# Altium schematic sheet [6]RGBs_2.SchDoc([6]RGBs_2)
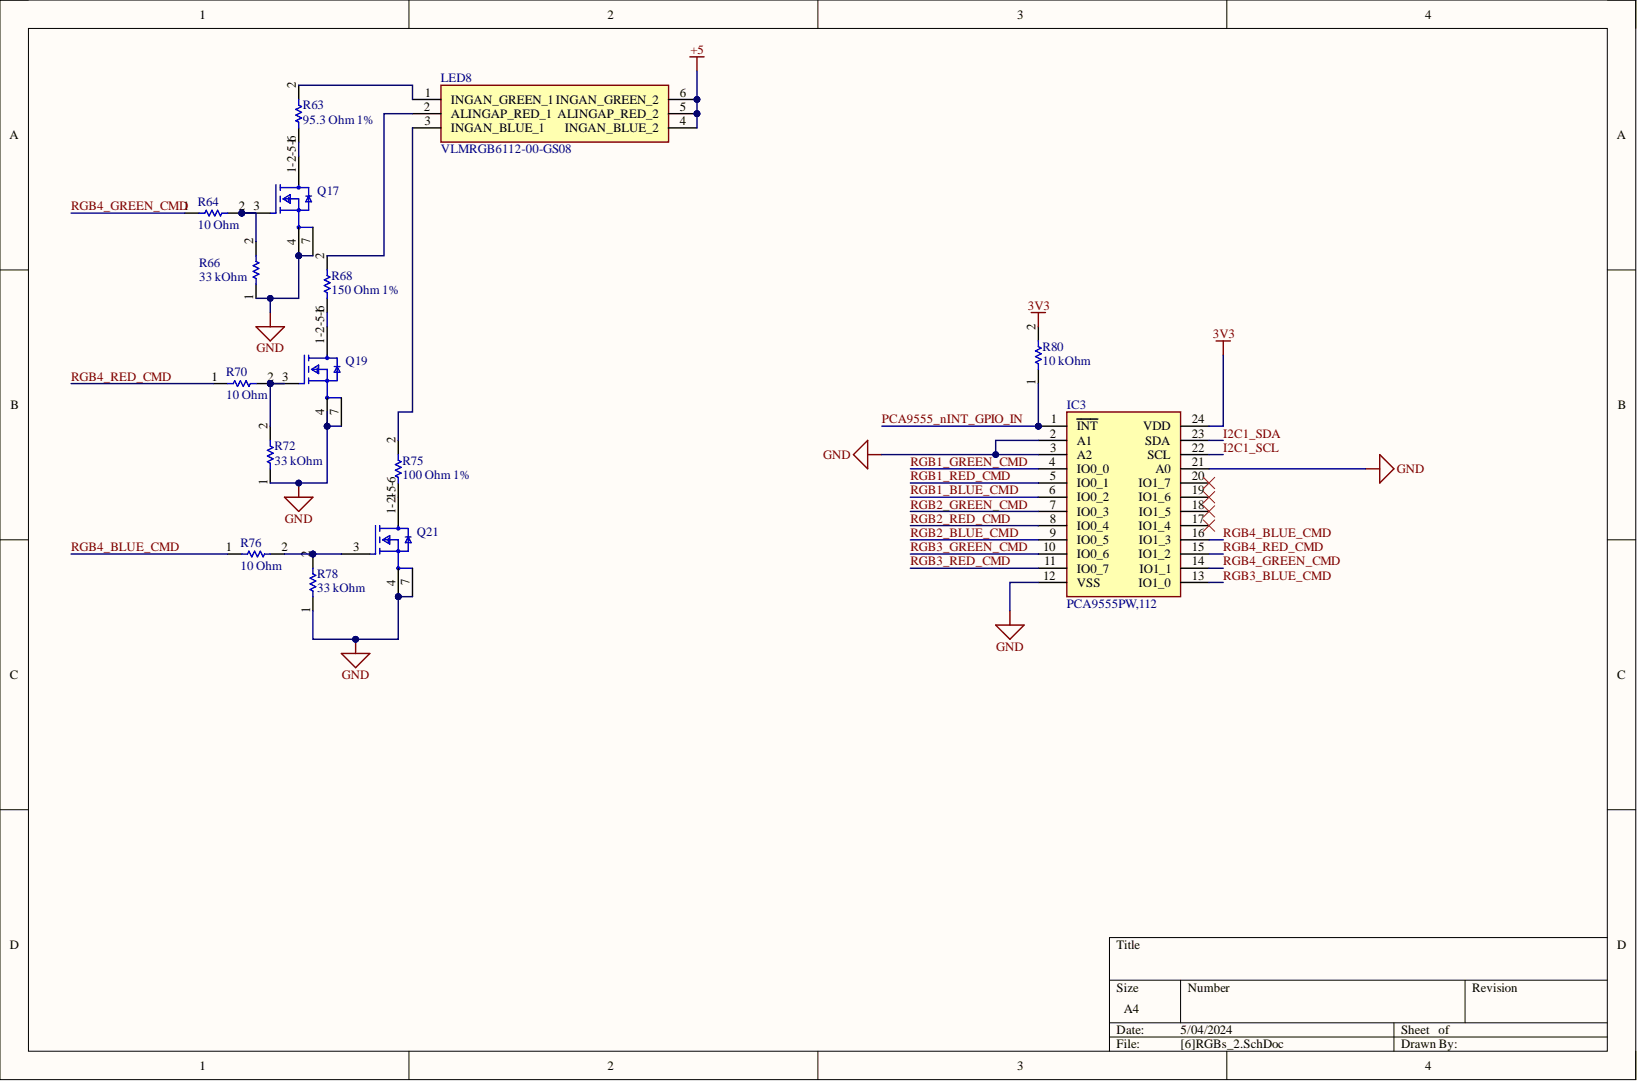
[diagram p0: [6]RGBs_2.SchDoc]

## Parts
IC3  PCA9555PW,112
LED8  VLMRGB6112-00-GS08
Q17  SIA440DJ-T1-GE3  (value not drawn)
Q19  SIA440DJ-T1-GE3  (value not drawn)
Q21  SIA440DJ-T1-GE3  (value not drawn)
R63  95.3 Ohm 1%
R64  10 Ohm
R66  33 kOhm
R68  150 Ohm 1%
R70  10 Ohm
R72  33 kOhm
R75  100 Ohm 1%
R76  10 Ohm
R78  33 kOhm
R80  10 kOhm

## Nets
3V3: IC3.24(VDD) R80.2
+5: LED8.4(INGAN_BLUE_2) LED8.5(ALINGAP_RED_2) LED8.6(INGAN_GREEN_2)
GND: IC3.2(A1) IC3.3(A2) IC3.12(VSS) IC3.21(A0) Q17.4 Q17.7 Q19.4 Q19.7 Q21.4 Q21.7 R66.1 R72.1 R78.1
I2C1_SCL: IC3.22(SCL)
I2C1_SDA: IC3.23(SDA)
PCA9555_nINT_GPIO_IN: IC3.1(INT) R80.1
RGB1_BLUE_CMD: IC3.6(IO0_2)
RGB1_GREEN_CMD: IC3.4(IO0_0)
RGB1_RED_CMD: IC3.5(IO0_1)
RGB2_BLUE_CMD: IC3.9(IO0_5)
RGB2_GREEN_CMD: IC3.7(IO0_3)
RGB2_RED_CMD: IC3.8(IO0_4)
RGB3_BLUE_CMD: IC3.13(IO1_0)
RGB3_GREEN_CMD: IC3.10(IO0_6)
RGB3_RED_CMD: IC3.11(IO0_7)
RGB4_BLUE_CMD: IC3.16(IO1_3) R76.1
RGB4_GREEN_CMD: IC3.14(IO1_1) R64.1
RGB4_RED_CMD: IC3.15(IO1_2) R70.1
NetLED8_1: LED8.1(INGAN_GREEN_1) R63.2
NetLED8_2: LED8.2(ALINGAP_RED_1) R68.2
NetLED8_3: LED8.3(INGAN_BLUE_1) R75.2
NetQ17_1-2-5-6: Q17.1-2-5-6 R63.1
NetQ17_3: Q17.3 R64.2 R66.2
NetQ19_1-2-5-6: Q19.1-2-5-6 R68.1
NetQ19_3: Q19.3 R70.2 R72.2
NetQ21_1-2-5-6: Q21.1-2-5-6 R75.1
NetQ21_3: Q21.3 R76.2 R78.2

Not connected: IC3 17(IO1_4) 18(IO1_5) 19(IO1_6) 20(IO1_7)

## Library data of the parts
IC3 = CMP-030-000057-1: PCA9555 16-bit I2C-bus and SMBus I/O port with interrupt; Manufacturer_Name: NXP; Arrow Part Number: PCA9555PW,112; Arrow Price/Stock: https://www.arrow.com/en/products/pca9555pw112/nxp-semiconductors?region=europe; Component Kind: Standard; Datasheet Link: http://www.nxp.com/docs/en/data-sheet/PCA9555.pdf; Design Item ID: PCA9555PW,112; Height: 1.1mm; Manufacturer_Part_Number: PCA9555PW,112; Mouser Part Number: 771-PCA9555PW; Mouser Price/Stock: https://www.mouser.co.uk/ProductDetail/NXP-Semiconductors/PCA9555PW112?qs=LOCUfHb8d9uevXd%252BxAh4lA%3D%3D; Source: SamacSys.SchLib; Library Name: EMEA- Squadra Corse PoliTO-  Politecnico di Torino
LED8 = CMP-031-000019-1: Vishay VLMRGB6112-00-GS08; Arrow Part Number: VLMRGB6112-00-GS08; Arrow Price/Stock: https://www.arrow.com/en/products/vlmrgb6112-00-gs08/vishay?region=nac; Component Kind: Standard; Datasheet Link: https://www.vishay.com/docs/84342/vlmrgb6112.pdf; Design Item ID: VLMRGB6112-00-GS08; Height: 1.45mm; Manufacturer_Name: Vishay; Manufacturer_Part_Number: VLMRGB6112-00-GS08; Mouser Part Number: 78-VLMRGB6112-00GS08; Mouser Price/Stock: https://www.mouser.co.uk/ProductDetail/Vishay-Semiconductors/VLMRGB6112-00-GS08?qs=r0GWHu4zPW7d7ePE7SUMgQ%3D%3D; Source: SamacSys.SchLib; Library Name: EMEA- Squadra Corse PoliTO-  Politecnico di Torino
Q17 Q19 Q21 = CMP-02550-000315-1: MOSFET N-CH 40V 12A PPAK SC70-6; Drain to Source Resistance: 26mR; Element Configuration: Single; Fall Time: 5ns; Gate to Source Voltage (Vgs): 12V; Input Capacitance: 700pF; Max Power Dissipation: 19W; Number of Channels: 1; Polarity: N-CHANNEL; Rise Time: 32ns; Turn-Off Delay Time: 23ns; Turn-On Delay Time: 12ns; Component Kind: Standard; Continuous Drain Current (ID): 12A; Drain to Source Breakdown Voltage: 40V; Drain to Source Voltage (Vdss): 40V; Height: 0.75mm; Length: 2.05mm; Library Name: Altium Content Vault; Max Operating Temperature: 150°C; Min Operating Temperature: -55°C; Mount: Surface Mount; Number of Pins: 6; Package Quantity: 3000; Packaging: Tape and Reel; Radiation Hardening: No; Rds On Max: 26mR; RoHS Compliant: Yes; Series: TrenchFET®; Width: 2.05mm
R63 = CMP-00010-3: CRCW060395R3FKTA; Component Kind: Standard; Library Name: EMEA- Squadra Corse PoliTO-  Politecnico di Torino
R64 R70 R76 = CMP-00010-3: CRCW060310R0FKEAHP; Component Kind: Standard; Library Name: EMEA- Squadra Corse PoliTO-  Politecnico di Torino
R66 R72 R78 = CMP-00010-3: CRCW060333K0JNEA; Component Kind: Standard; Library Name: EMEA- Squadra Corse PoliTO-  Politecnico di Torino
R68 = CMP-00010-3: CRCU0603150RFKEAHP; Component Kind: Standard; Library Name: EMEA- Squadra Corse PoliTO-  Politecnico di Torino
R75 = CMP-00010-3: CRCW0603100RFKEAHP; Component Kind: Standard; Library Name: EMEA- Squadra Corse PoliTO-  Politecnico di Torino
R80 = CMP-00010-3: CRCW0S0310K0FKEB; Component Kind: Standard; Library Name: EMEA- Squadra Corse PoliTO-  Politecnico di Torino
